# Altium schematic sheet Principal.SchDoc(Principal)
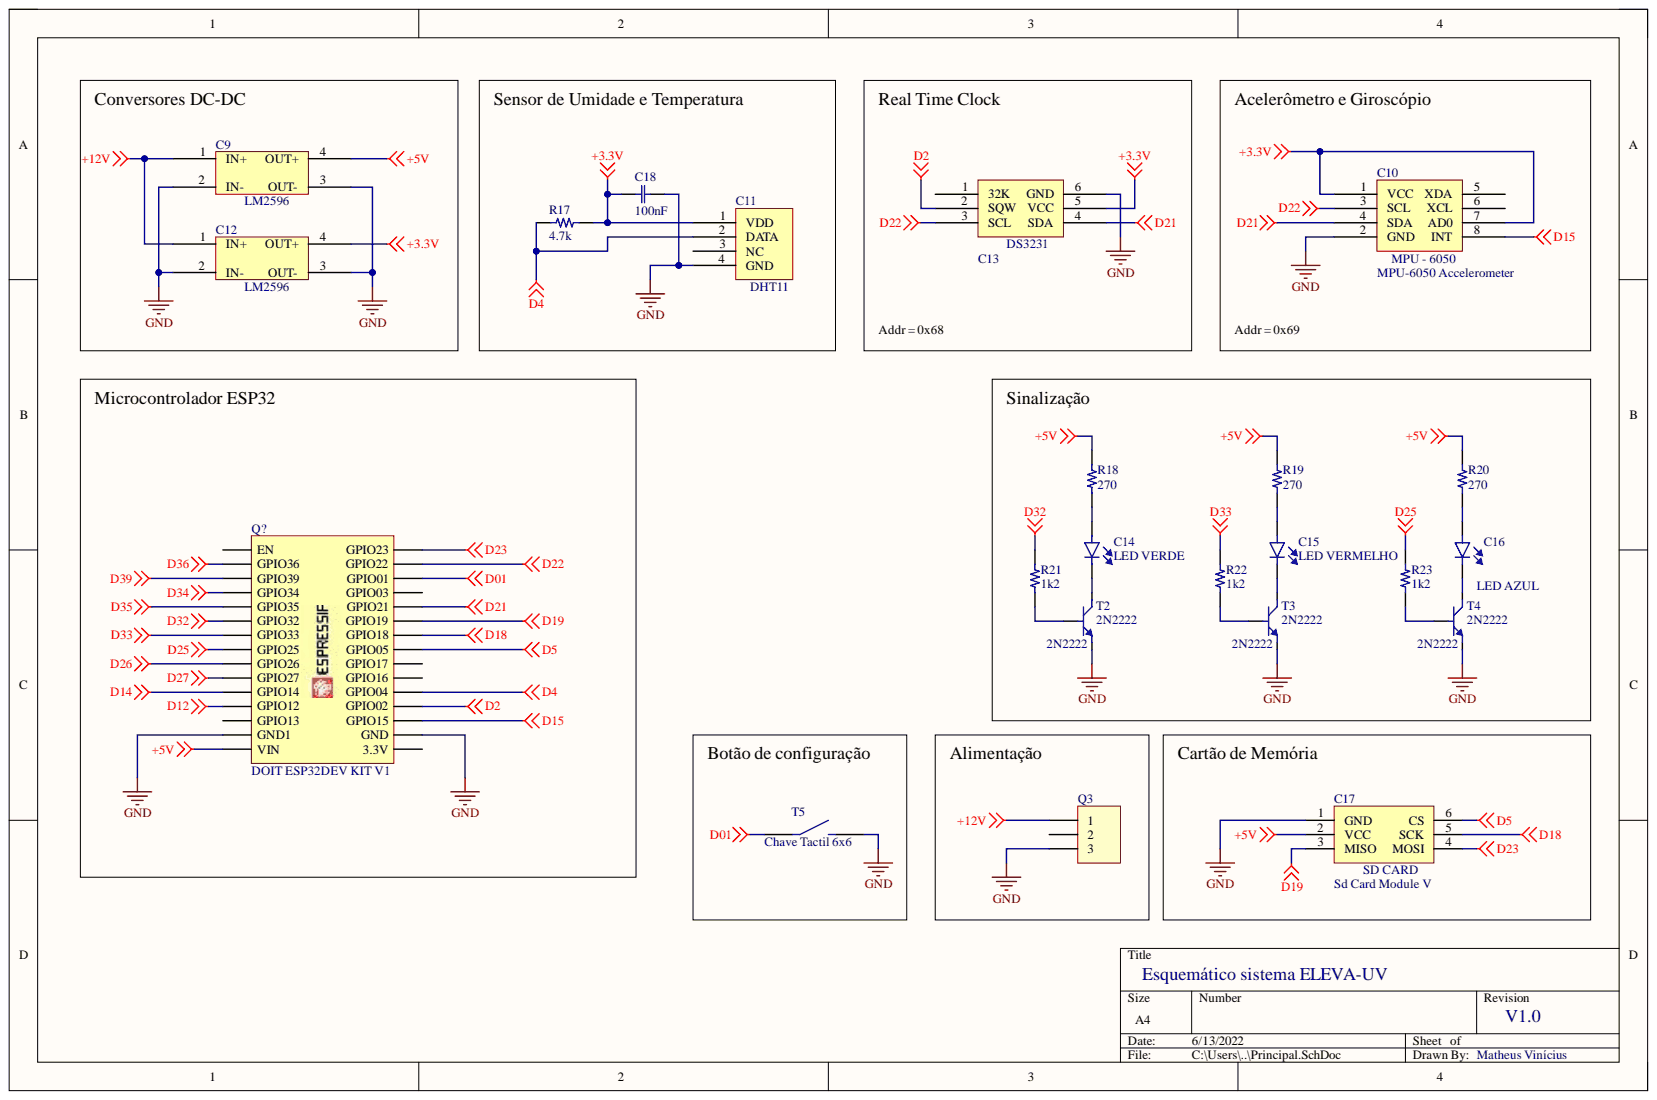
[diagram p0: Principal.SchDoc]

## Parts
C9  Module LM2596S  [Module LM2596S-Footprint-1]  (value not drawn)
C10  MPU-6050 Accelerometer  [MPU-6050 Accelerometer-Footprint-1]
C11  DHT11  [DHT11-Footprint-1]
C12  Module LM2596S  [Module LM2596S-Footprint-1]  (value not drawn)
C13  Real Time Clock DS3231 Module  [Real Time Clock DS3231 Module-Footprint-1]  (value not drawn)
C14  LED VERDE  [LED 5mm-Footprint-1]
C15  LED VERMELHO  [LED 5mm-Footprint-1]
C16  LED AZUL  [LED 5mm-Footprint-1]
C17  Sd Card Module V  [Sd Card Module V-Footprint-1]
C18  100nF  [CAP CERAMIC DIA 5mm-Footprint-1]
Q3  BORNE KRE 3-Vias  [BORNE KRE 3-Vias-Footprint-1]  (value not drawn)
Q?  DOIT ESP32DEV KIT V1  [DOIT ESP32DEV KIT V1-Footprint-1]
R17  4.7k  [RESISTOR 1_4W-Footprint-1]
R18  270  [RESISTOR 1_4W-Footprint-1]
R19  270  [RESISTOR 1_4W-Footprint-1]
R20  270  [RESISTOR 1_4W-Footprint-1]
R21  1k2  [RESISTOR 1_4W-Footprint-1]
R22  1k2  [RESISTOR 1_4W-Footprint-1]
R23  1k2  [RESISTOR 1_4W-Footprint-1]
T2  2N2222  [2N2222-Footprint-1]
T3  2N2222  [2N2222-Footprint-1]
T4  2N2222  [2N2222-Footprint-1]
T5  Chave Tactil 6x6  [Chave Tactil 6x6-Footprint-1]

## Nets
+3.3V: C10.1(VCC) C10.7(AD0) C11.1(VDD) C12.4(OUT+) C13.5(VCC) C18.2 R17.2
+5V: C9.4(OUT+) C17.2(VCC) Q?.1(VIN) R18.2 R19.2 R20.2
+12V: C9.1(IN+) C12.1(IN+) Q3.1
D01: Q?.18(GPIO01) T5.1
D2: C13.2(SQW) Q?.27(GPIO02)
D4: C11.2(DATA) Q?.26(GPIO04) R17.1
D5: C17.6(CS) Q?.23(GPIO05)
D12: Q?.4(GPIO12)
D14: Q?.5(GPIO14)
D15: C10.8(INT) Q?.28(GPIO15)
D18: C17.5(SCK) Q?.22(GPIO18)
D19: C17.3(MISO) Q?.21(GPIO19)
D21: C10.4(SDA) C13.4(SDA) Q?.20(GPIO21)
D22: C10.3(SCL) C13.3(SCL) Q?.17(GPIO22)
D23: C17.4(MOSI) Q?.16(GPIO23)
D25: Q?.8(GPIO25) R23.1
D26: Q?.7(GPIO26)
D27: Q?.6(GPIO27)
D32: Q?.10(GPIO32) R21.1
D33: Q?.9(GPIO33) R22.1
D34: Q?.12(GPIO34)
D35: Q?.11(GPIO35)
D36: Q?.14(GPIO36)
D39: Q?.13(GPIO39)
GND: C9.2(IN-) C9.3(OUT-) C10.2(GND) C11.4(GND) C12.2(IN-) C12.3(OUT-) C13.6(GND) C17.1(GND) C18.1 Q3.3 Q?.2(GND1) Q?.29(GND) T2.3 T3.3 T4.3 T5.2
NetC14_1: C14.1 R18.1
NetC14_2: C14.2 T2.1
NetC15_1: C15.1 R19.1
NetC15_2: C15.2 T3.1
NetC16_1: C16.1 R20.1
NetC16_2: C16.2 T4.1
NetR21_2: R21.2 T2.2
NetR22_2: R22.2 T3.2
NetR23_2: R23.2 T4.2

Not connected: C10 5(XDA) 6(XCL); C11 3(NC); C13 1(32K); Q3 2; Q? 3(GPIO13) 15(EN) 19(GPIO03) 24(GPIO17) 25(GPIO16) 30(3.3V)

## Library data of the parts
C9 C12 = CMP-00000-4: Step down converter; Component Kind: Standard; Library Name: Eletrônica 2
C10 = CMP-00002-1: Accelerometer, Gyroscope, 3 axis sensor; Component Kind: Standard; Library Name: Eletrônica 2
C11 = CMP-00005-1: DHT11 Temperature and Humidity sensor; Component Kind: Standard; Library Name: Eletrônica 2
C13 = CMP-00004-1: Component Kind: Standard; Description: Real Time Clock DS3231 Module; Library Name: Eletrônica 2
C14-C16 = CMP-00006-2: LED 5mm; Component Kind: Standard; Library Name: Eletrônica 2
C17 = CMP-00013-1: Sd Card Module Vertical; Component Kind: Standard; Library Name: Eletrônica 2
C18 = CMP-00011-2: DIAMETER 5mm PITCH 2mm; Component Kind: Standard; Library Name: Eletrônica 2
Q3 = CMP-00014-1: Component Kind: Standard; Description: BORNE KRE 3-Vias; Library Name: Eletrônica 2
Q? = CMP-00012-1: Microcontroller ESP32; Component Kind: Standard; Datasheet: https://github.com/playelek/pinout-doit-32devkitv1; Library Name: Eletrônica 2
R17-R23 = CMP-00007-2: Component Kind: Standard; Library Name: Eletrônica 2
T2-T4 = CMP-00010-1: Transistor 2N2222; Component Kind: Standard; Library Name: Eletrônica 2
T5 = CMP-00015-1: Component Kind: Standard; Library Name: Eletrônica 2
